# Altium schematic sheet FTDI_SBW.SchDoc(FTDI_SBW)
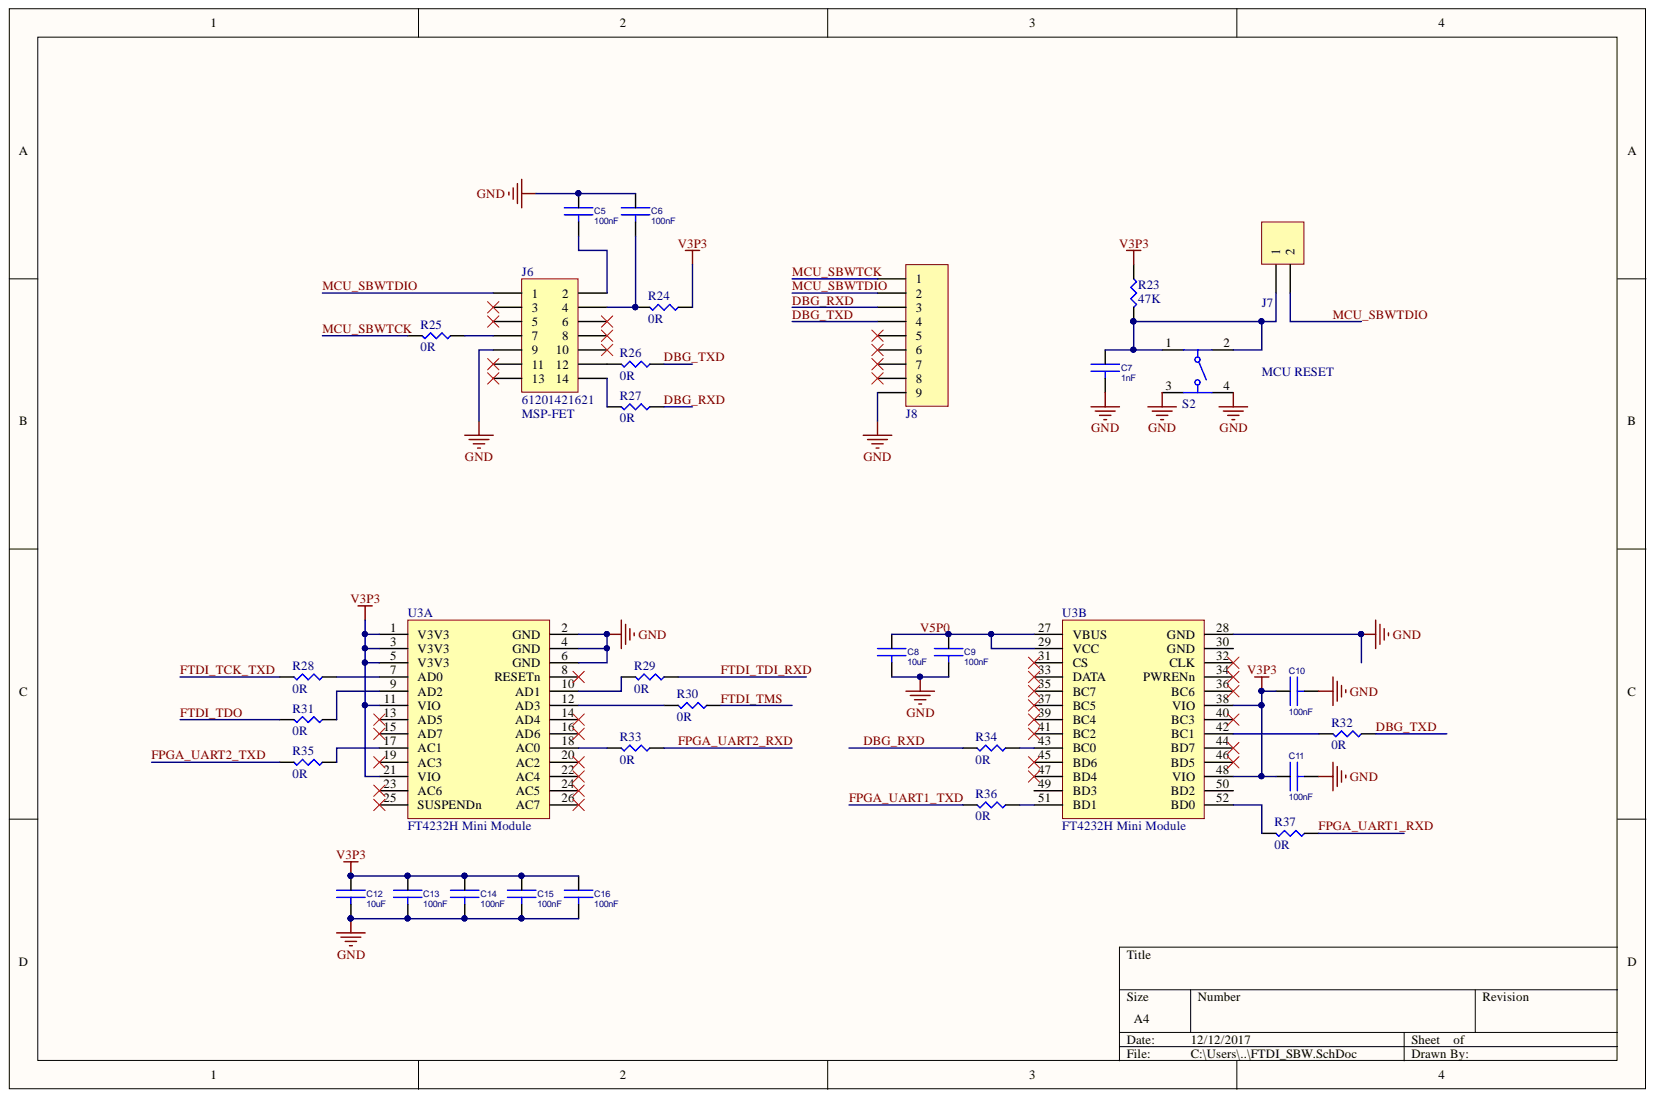
[diagram p0: FTDI_SBW.SchDoc]

## Parts
C5  100nF  [0603C]
C6  100nF  [0603C]
C7  1nF  [0603C]
C8  10uF  [C0805]
C9  100nF  [0603C]
C10  100nF  [0603C]
C11  100nF  [0603C]
C12  10uF  [C0805]
C13  100nF  [0603C]
C14  100nF  [0603C]
C15  100nF  [0603C]
C16  100nF  [0603C]
J6  61201421621  [61201421621]
J7  61300211121  [61300211121]  (value not drawn)
J8  61300911121  [61300911121]  (value not drawn)
R23  47K  [R0603]
R24  0R  [R0603]
R25  0R  [R0603]
R26  0R  [R0603]
R27  0R  [R0603]
R28  0R  [R0603]
R29  0R  [R0603]
R30  0R  [R0603]
R31  0R  [R0603]
R32  0R  [R0603]
R33  0R  [R0603]
R34  0R  [R0603]
R35  0R  [R0603]
R36  0R  [R0603]
R37  0R  [R0603]
S2  430773034825  [4307x30348xx_6x6x3.4]  (value not drawn)
U3  FT4232H Mini Module  [FT2232H_MOD]  (parts A,B)

## Nets
GND: C5.1 C6.1 C7.2 C8.2 C9.2 C10.2 C11.2 C12.2 C13.2 C14.2 C15.2 C16.2 J6.9 J8.9 S2.3 S2.4 U3.2(GND) U3.4(GND) U3.6(GND) U3.28(GND)
V3P3: C10.1 C11.1 C12.1 C13.1 C14.1 C15.1 C16.1 R23.1 R24.1 U3.1(V3V3) U3.3(V3V3) U3.5(V3V3) U3.11(VIO) U3.21(VIO) U3.38(VIO) U3.48(VIO)
DBG_RXD: J8.3 R27.1 R34.2
DBG_TXD: J8.4 R26.1 R32.1
FPGA_UART1_RXD: R37.1
FPGA_UART1_TXD: R36.2
FPGA_UART2_RXD: R33.1
FPGA_UART2_TXD: R35.2
FTDI_TCK_TXD: R28.2
FTDI_TDI_RXD: R29.1
FTDI_TDO: R31.2
FTDI_TMS: R30.1
MCU_SBWTCK: J8.1 R25.2
MCU_SBWTDIO: J6.1 J7.2 J8.2
V5P0: C8.1 C9.1 U3.27(VBUS) U3.29(VCC)
NetC5_2: C5.2 J6.2
NetC6_2: C6.2 J6.4 R24.2
NetC7_1: C7.1 J7.1 R23.2 S2.1 S2.2
NetJ6_7: J6.7 R25.1
NetJ6_12: J6.12 R26.2
NetJ6_14: J6.14 R27.2
NetR28_1: R28.1 U3.7(AD0)
NetR29_2: R29.2 U3.10(AD1)
NetR30_2: R30.2 U3.12(AD3)
NetR31_1: R31.1 U3.9(AD2)
NetR32_2: R32.2 U3.42(BC1)
NetR33_2: R33.2 U3.18(AC0)
NetR34_1: R34.1 U3.43(BC0)
NetR35_1: R35.1 U3.17(AC1)
NetR36_1: R36.1 U3.51(BD1)
NetR37_2: R37.2 U3.52(BD0)

Not connected: J6 3 5 6 8 10 11 13; J8 5 6 7 8; U3 8(RESETn) 13(AD5) 14(AD4) 15(AD7) 16(AD6) 19(AC3) 20(AC2) 22(AC4) 23(AC6) 24(AC5) 25(SUSPENDn) 26(AC7) 30(GND) 31(CS) 32(CLK) 33(DATA) 34(PWRENn) 35(BC7) 36(BC6) 37(BC5) 39(BC4) 40(BC3) 41(BC2) 44(BD7) 45(BD6) 46(BD5) 47(BD4) 49(BD3) 50(BD2)

## Library data of the parts
C5-C7 C9-C11 C13-C16 = 100nF/0603: Description 1: CAP CER 0.1UF 25V 10% X7R 0603; Supplier Part Number 1: 311-1341-6-ND; Supplier 1: Digi-Key; Library Name: Control_Board.SchLib; Component Kind: Standard
C8 C12 = 10uF/0805/16V: Description 1: CAP CER 10UF 16V X5R 0805; Supplier Part Number 1: 478-5165-2-ND; Supplier 1: Digi-Key; Library Name: Control_Board.SchLib; Component Kind: Standard
J6 = 61201421621: WR-BHD 2.54mm Male Box Header; Manufacturer: Wurth Elektronik; NoPins: 14; ComponentLink2 Description: Datasheet; ComponentLink1 Description: Manufacturer; partNo: 61201421621; Library Name: ALTIUM-BHD_2_54_MALE_BOX_HEADER_6120XX21621.IntLib; Created Date: March 2016; ComponentLink2URL: http://katalog.we-online.de/em/datasheet/6120xx21621.pdf; ComponentLink1URL: http://www.we-online.com; Component Kind: Standard; Created by: Baojun Chen
J7 = 61300211121: WR-PHD 2.54 mm THT Pin Header, 2p; Working Voltage: 250 VAC; Withstanding Voltage: 500 VAC; Rated Current: 3 A; Pitch: 2.54 mm; Pin Number: 2; Published Date: Aug 2017; Part Number: 61300211121; Library Name: Altium_WR-PHD_PIN_HEADER_2_54 (rev17a).IntLib; ComponentLink2URL: http://katalog.we-online.de/em/datasheet/6130xx11121.pdf; ComponentLink2Description: Datasheet; ComponentLink1URL: http://www.we-online.de; ComponentLink1Description: Manufacturer; Component Kind: Standard; Publisher: Wurth Elektronik
J8 = 61300911121: WR-PHD 2.54 mm THT Pin Header, 9p; Working Voltage: 250 VAC; Withstanding Voltage: 500 VAC; Rated Current: 3 A; Pitch: 2.54 mm; Pin Number: 9; Published Date: Aug 2017; Part Number: 61300911121; Library Name: Altium_WR-PHD_PIN_HEADER_2_54 (rev17a).IntLib; ComponentLink2URL: http://katalog.we-online.de/em/datasheet/6130xx11121.pdf; ComponentLink2Description: Datasheet; ComponentLink1URL: http://www.we-online.de; ComponentLink1Description: Manufacturer; Component Kind: Standard; Publisher: Wurth Elektronik
R23-R37 = 1K/1%/0603: Description 1: Thick Film Resistors - SMD 1K OHM 1%; Supplier Part Number 1: 311-1.00KHRCT-ND; Supplier 1: Digi-Key; Library Name: Control_Board.SchLib; Component Kind: Standard
S2 = 430773034825: WS-TASV 6x6mm J-Hook Hermetic Tact Switch, SMD version; Operation Force: 250g; Size: 6x6x3.4 mm; Published Date: June 2017; Part Number: 430773034825; Life Cycle: 500000 cycles; Library Name: Altium_WS-TASV (rev17a).IntLib; ComponentLink2URL: http://katalog.we-online.de/em/datasheet/430773034825.pdf; ComponentLink2Description: Datasheet; ComponentLink1URL: http://www.we-online.de; ComponentLink1Description: Manufacturer; Component Kind: Standard; Publisher: Wurth Elektronik
U3 = FT2232H_MOD: Description 1: FT2232 Mini Module, USB to Serial/FIFO; Supplier Part Number 1: FT2232H; Supplier 1: FTDI Chip; Component Kind: Standard; Library Name: AMOLED.SchLib
